# Altium schematic sheet 2-2  辅助电路.SchDoc("2-2  辅助电路")
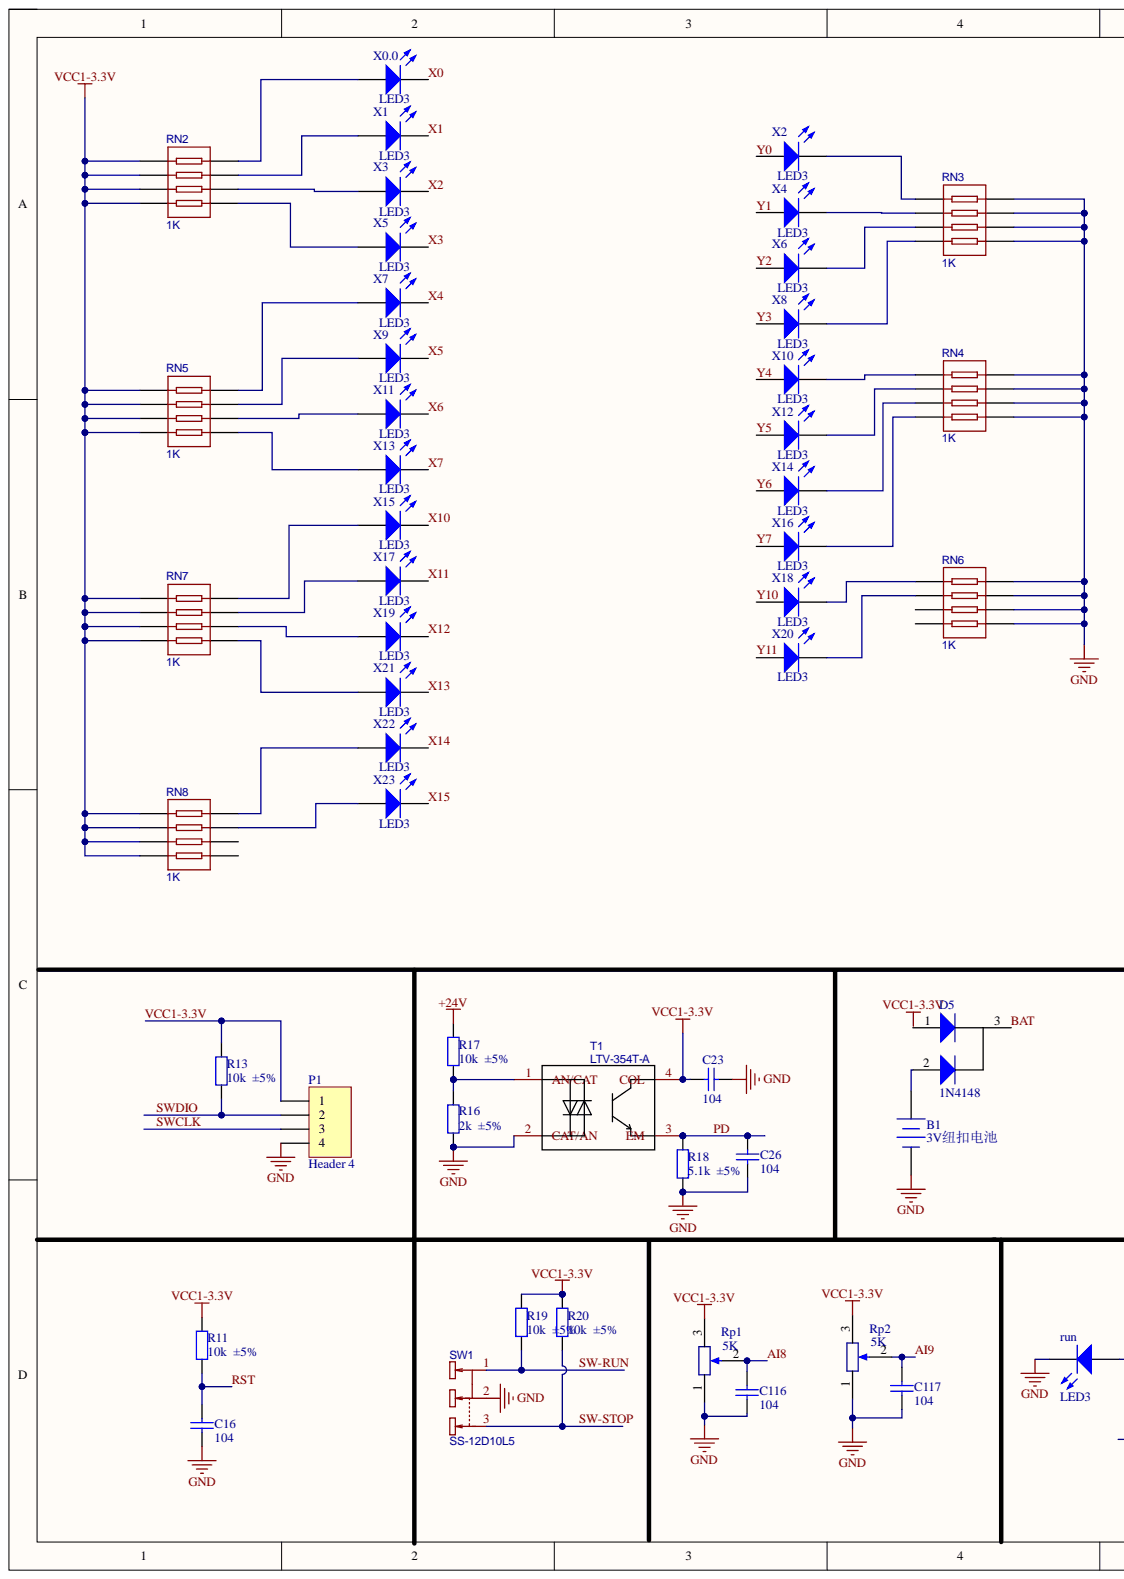
[diagram p0: 2-2  辅助电路.SchDoc, left]
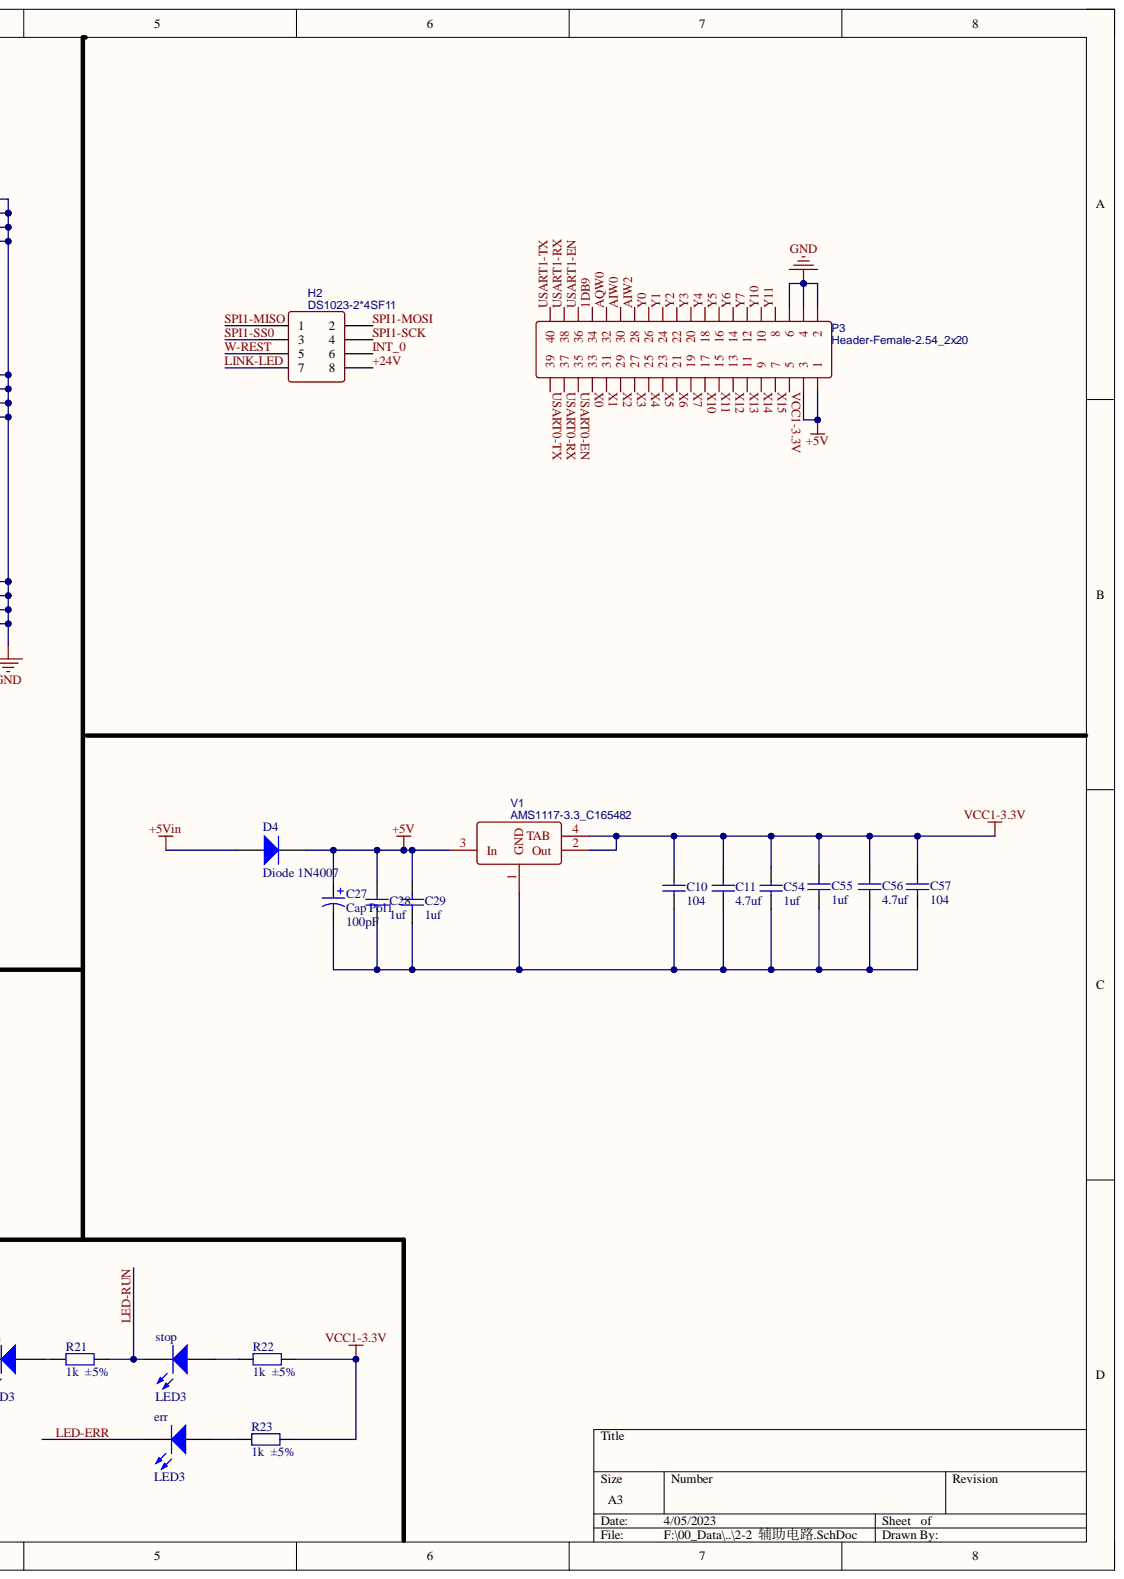
[diagram p1: 2-2  辅助电路.SchDoc, right]

## Parts
B1  3V纽扣电池  [Battery_3V固定底座]
C10  104  [1206_C]
C11  4.7uf  [1206_C]
C16  104  [0805_C]
C23  104  [0805_C]
C26  104  [0805_C]
C27  Cap Pol1  value 100pF  [RB7.6-15]
C28  1uf  [1206_C]
C29  1uf  [1206_C]
C54  1uf  [1206_C]
C55  1uf  [1206_C]
C56  4.7uf  [1206_C]
C57  104  [1206_C]
C116  104  [0805_C]
C117  104  [0805_C]
D4  Diode 1N4007  [DO-41]
D5  1N4148  [SOT-23(SOT-23-3)]
err  LED3  [3.5X2.8X1.9]
H2  DS1023-2*4SF11  [HDR-TH_8P-P2.54-V-R2-C4-S2.54]
P1  Header 4  [HDR1X4]
P3  Header-Female-2.54_2x20  [HDR-TH_40P-P2.54-V-R2-C20-S2.54]
R11  10k  ±5%  [0603_R]
R13  10k  ±5%  [0603_R]
R16  2k  ±5%  [0603_R]
R17  10k  ±5%  [0603_R]
R18  5.1k  ±5%  [0603_R]
R19  10k  ±5%  [0603_R]
R20  10k  ±5%  [0603_R]
R21  1k  ±5%  [0603_R]
R22  1k  ±5%  [0603_R]
R23  1k  ±5%  [0603_R]
RN2  1K  [RES-ARRAY-SMD_0603-8P-L3.2-W1.6-BL]
RN3  1K  [RES-ARRAY-SMD_0603-8P-L3.2-W1.6-BL]
RN4  1K  [RES-ARRAY-SMD_0603-8P-L3.2-W1.6-BL]
RN5  1K  [RES-ARRAY-SMD_0603-8P-L3.2-W1.6-BL]
RN6  1K  [RES-ARRAY-SMD_0603-8P-L3.2-W1.6-BL]
RN7  1K  [RES-ARRAY-SMD_0603-8P-L3.2-W1.6-BL]
RN8  1K  [RES-ARRAY-SMD_0603-8P-L3.2-W1.6-BL]
Rp1  5K  [RP-3362]
Rp2  5K  [RP-3362]
run  LED3  [3.5X2.8X1.9]
stop  LED3  [3.5X2.8X1.9]
SW1  SS-12D10L5  [SW-TH_SS-12D10L5]
T1  LTV-354T-A  [SOP-4_L3.9-W4.4-P2.54-LS7.0-BR]
V1  AMS1117-3.3_C165482  [SOT-223_L6.5-W3.5-P2.30-LS7.0-BR]
X0.0  LED3  [3.5X2.8X1.9]
X1  LED3  [3.5X2.8X1.9]
X2  LED3  [3.5X2.8X1.9]
X3  LED3  [3.5X2.8X1.9]
X4  LED3  [3.5X2.8X1.9]
X5  LED3  [3.5X2.8X1.9]
X6  LED3  [3.5X2.8X1.9]
X7  LED3  [3.5X2.8X1.9]
X8  LED3  [3.5X2.8X1.9]
X9  LED3  [3.5X2.8X1.9]
X10  LED3  [3.5X2.8X1.9]
X11  LED3  [3.5X2.8X1.9]
X12  LED3  [3.5X2.8X1.9]
X13  LED3  [3.5X2.8X1.9]
X14  LED3  [3.5X2.8X1.9]
X15  LED3  [3.5X2.8X1.9]
X16  LED3  [3.5X2.8X1.9]
X17  LED3  [3.5X2.8X1.9]
X18  LED3  [3.5X2.8X1.9]
X19  LED3  [3.5X2.8X1.9]
X20  LED3  [3.5X2.8X1.9]
X21  LED3  [3.5X2.8X1.9]
X22  LED3  [3.5X2.8X1.9]
X23  LED3  [3.5X2.8X1.9]

## Nets
+5V: C27.1 C28.2 C29.2 D4.2 P3.1 P3.3 V1.3(In)
+5Vin: D4.1
GND: B1.2 C16.2 C23.2 C26.1 C116.1 C117.1 P1.4 P3.2 P3.4 P3.6 R16.1 R18.2 RN3.1 RN3.2 RN3.3 RN3.4 RN4.1 RN4.2 RN4.3 RN4.4 RN6.1 RN6.2 RN6.3 RN6.4 Rp1.1 Rp2.1 run.2 SW1.2 T1.2(CAT/AN)
1DB9: P3.34
+24V: H2.8 R17.1
AI8: C116.2 Rp1.2
AI9: C117.2 Rp2.2
AIW0: P3.30
AIW2: P3.28
AQW0: P3.32
BAT: D5.3
INT_0: H2.6
LED-ERR: err.2
LED-RUN: R21.2 stop.2
LINK-LED: H2.7
PD: C26.2 R18.1 T1.3(EM)
RST: C16.1 R11.1
SPI1-MISO: H2.1
SPI1-MOSI: H2.2
SPI1-SCK: H2.4
SPI1-SS0: H2.3
SW-RUN: R19.2 SW1.1
SW-STOP: R20.2 SW1.3
SWCLK: P1.3
SWDIO: P1.2 R13.1
USART0-EN: P3.35
USART0-RX: P3.37
USART0-TX: P3.39
USART1-EN: P3.36
USART1-RX: P3.38
USART1-TX: P3.40
VCC1-3.3V: C10.2 C11.2 C23.1 C54.2 C55.2 C56.2 C57.2 P1.1 P3.5 R11.2 R13.2 R19.1 R20.1 R22.1 R23.1 RN2.5 RN2.6 RN2.7 RN2.8 RN5.5 RN5.6 RN5.7 RN5.8 RN7.5 RN7.6 RN7.7 RN7.8 RN8.5 RN8.6 RN8.7 RN8.8 Rp1.3 Rp2.3 T1.4(COL) V1.2(Out) V1.4(TAB)
W-REST: H2.5
X0: P3.33 X0.0.2
X1: P3.31 X1.2
X2: P3.29 X3.2
X3: P3.27 X5.2
X4: P3.25 X7.2
X5: P3.23 X9.2
X6: P3.21 X11.2
X7: P3.19 X13.2
X10: P3.17 X15.2
X11: P3.15 X17.2
X12: P3.13 X19.2
X13: P3.11 X21.2
X14: P3.9 X22.2
X15: P3.7 X23.2
Y0: P3.26 X2.1
Y1: P3.24 X4.1
Y2: P3.22 X6.1
Y3: P3.20 X8.1
Y4: P3.18 X10.1
Y5: P3.16 X12.1
Y6: P3.14 X14.1
Y7: P3.12 X16.1
Y10: P3.10 X18.1
Y11: P3.8 X20.1
NetB1_1: B1.1 D5.2
NetC10_1: C10.1 C11.1 C27.2 C28.1 C29.1 C54.1 C55.1 C56.1 C57.1 V1.1(GND)
Neterr_1: err.1 R23.2
NetR16_2: R16.2 R17.2 T1.1(AN/CAT)
NetR21_1: R21.1 run.1
NetR22_2: R22.2 stop.1
NetRN2_1: RN2.1 X5.1
NetRN2_2: RN2.2 X3.1
NetRN2_3: RN2.3 X1.1
NetRN2_4: RN2.4 X0.0.1
NetRN3_5: RN3.5 X2.2
NetRN3_6: RN3.6 X4.2
NetRN3_7: RN3.7 X6.2
NetRN3_8: RN3.8 X8.2
NetRN4_5: RN4.5 X10.2
NetRN4_6: RN4.6 X12.2
NetRN4_7: RN4.7 X14.2
NetRN4_8: RN4.8 X16.2
NetRN5_1: RN5.1 X13.1
NetRN5_2: RN5.2 X11.1
NetRN5_3: RN5.3 X9.1
NetRN5_4: RN5.4 X7.1
NetRN6_5: RN6.5 X18.2
NetRN6_6: RN6.6 X20.2
NetRN7_1: RN7.1 X21.1
NetRN7_2: RN7.2 X19.1
NetRN7_3: RN7.3 X17.1
NetRN7_4: RN7.4 X15.1
NetRN8_3: RN8.3 X23.1
NetRN8_4: RN8.4 X22.1

Not connected: D5 1; RN6 7 8; RN8 1 2

## Library data of the parts
B1 = Battery_3V: Multicell Battery; Component Kind: Standard; HelpURL: https://item.taobao.com/item.htm?spm=a230r.1.14.68.53d71be0dp6FL3&id=538548243439&ns=1&abbucket=20#detail; Library Name: 02-Miscellaneous_Devices_ZSF_Library.IntLib
C10 C11 C28 C29 C54-C57 = Cap_1206: 1206贴片电容; Component Kind: Standard; Library Name: 02-Miscellaneous_Devices_ZSF_Library.IntLib; Package: 0805; 常见贴片电容尺寸图: https://wenku.baidu.com/view/21d5206100f69e3143323968011ca300a6c3f6b2.html
  C10 C57: Value: 104
  C11 C56: Value: 4.7uf
  C28 C29 C54 C55: Value: 1uf
C16 C23 C26 C116 C117 = Cap_0805: 0805贴片电容; Component Kind: Standard; Library Name: 02-Miscellaneous_Devices_ZSF_Library.IntLib; Package: 0805; Value: 104; 常见贴片电容尺寸图: https://wenku.baidu.com/view/21d5206100f69e3143323968011ca300a6c3f6b2.html
C27 = Cap Pol1: Polarized Capacitor (Radial); Component Kind: Standard; LatestRevisionDate: 17-Jul-2002; LatestRevisionNote: Re-released for DXP Platform.; Library Name: Miscellaneous Devices.IntLib; PackageDescription: Polarized Capacitor (Radial); 2 Leads; PackageReference: RB7.6-15; Published: 8-Jun-2000; Signal Integrity: Cap Pol; Simulation: CAP; Publisher: Altium Limited
D4 = Diode 1N4007: 1 Amp General Purpose Rectifier; Code_JEDEC: DO-204-AL; Component Kind: Standard; ComponentLink1Description: Manufacturer Link; ComponentLink1URL: http://www.fairchildsemi.com/; ComponentLink2Description: Datasheet; ComponentLink2URL: http://www.fairchildsemi.com/ds/1N%2F1N4001.pdf; DatasheetVersion: 1998; LatestRevisionDate: 17-Jul-2002; LatestRevisionNote: Re-released for DXP Platform.; Library Name: Miscellaneous Devices.IntLib; PackageDescription: Diode, Axial; Body 5.2 x 2.7 mm (LxDia. max), Lead Dia. 0.86 mm (max); PackageReference: DO-41; PackageVersion: Aug-1999; Published: 8-Jun-2000; Signal Integrity: 1N4007; Simulation: 1N4007; Publisher: Altium Limited
D5 = BAV70 A4 SOT-23: 开关二极管; Component Kind: Standard; ComponentLink1Description: 供应商链接; ComponentLink1URL: http://www.szlcsc.com/product/details_70091.html; Library Name: 02-Miscellaneous_Devices_ZSF_Library.IntLib; Package: SOT-23; Supplier: LC; SuppliersPartNumber: C68978
err run stop X0.0 X1-X23 = LED3: Typical BLUE SiC LED; Component Kind: Standard; DatasheetVersion: 16-Apr-2002; LatestRevisionDate: 17-Dec-2003; LatestRevisionNote: PCB Footprint replaced.; Library Name: Miscellaneous Devices.IntLib; PackageDescription: SM LED; 2 C-Bend Leads; Body 3.5 x 2.8 x 1.9 mm, inc leads (LxWxH typ); PackageReference: 3.5X2.8X1.9; PackageVersion: 16-Apr-2002; Published: 8-Jun-2000; Signal Integrity: LED; Simulation: LED3; Publisher: Altium Limited
H2 = DS1023-2*4SF11: BOM_Manufacturer: CONNFLY; Contributor: LCSC; BOM_JLCPCB Part Class: Extended Part; BOM_Manufacturer Part: DS1023-2*4SF11; BOM_Supplier: LCSC; BOM_Supplier Part: C92271; Component Kind: Standard; spicePre: H; spiceSymbolName: DS1023-2*4SF11
P1 = Header 4: Header, 4-Pin; Component Kind: Standard; LatestRevisionDate: 17-Jul-2002; LatestRevisionNote: Re-released for DXP Platform.; Library Name: Miscellaneous Connectors.IntLib; Signal Integrity: Connector; Publisher: Altium Limited
P3 = Header-Female-2.54_2x20: BOM_Manufacturer: BOOMELE; BOM_Manufacturer Part: 2.54mm 2*20PFemale header; BOM_JLCPCB Part Class: Extended Part; BOM_Supplier: LCSC; BOM_Supplier Part: C50982; Component Kind: Standard; spicePre: P; spiceSymbolName: Header-Female-2.54_2x20
R11 R13 R16-R23 = Res_0603: 贴片电阻; Component Kind: Standard; ComponentLink1Description: 供应商链接; ComponentLink1URL: http://www.szlcsc.com/product/details_21903.html; Library Name: 02-Miscellaneous_Devices_ZSF_Library.IntLib; Package: 0603; Supplier: LC; SuppliersPartNumber: C21189
RN2-RN8 = 4.7K: BOM_Manufacturer: Ever Ohms Tech; BOM_Manufacturer Part: CRA034RF4K7P05; Contributor: LCSC; nameAlias: Resistance (Ohms); BOM_JLCPCB Part Class: Extended Part; BOM_Supplier: LCSC; BOM_Supplier Part: C149871; Component Kind: Standard; spicePre: R; spiceSymbolName: CRA034RF4K7P05
Rp1 Rp2 = RP_3362: 3296电位器; Component Kind: Standard; Library Name: 02-Miscellaneous_Devices_ZSF_Library.IntLib; 购买链接: https://detail.tmall.com/item.htm?spm=a230r.1.14.6.6b126f99GzSWkb&id=551070304702&cm_id=140105335569ed55e27b&abbucket=13
SW1 = SS-12D10L5: BOM_Manufacturer: XKB Enterprise; Contributor: LCSC; BOM_JLCPCB Part Class: Extended Part; BOM_Manufacturer Part: SS-12D10L5; BOM_Supplier: LCSC; BOM_Supplier Part: C319012; Component Kind: Standard; JLCPCB Part Class: Extended Part; spicePre: S; spiceSymbolName: SS-12D10L5
T1 = LTV-354T-A: BOM_Manufacturer: LITEON; BOM_JLCPCB Part Class: Extended Part; BOM_Manufacturer Part: LTV-354T-A; BOM_Supplier: LCSC; BOM_Supplier Part: C10802; Component Kind: Standard; JLCPCB Part Class: Extended Part; spicePre: U; spiceSymbolName: LTV-354T-A
V1 = AMS1117-3.3_C165482: BOM_Manufacturer: SK; Contributor: LCSC; BOM_JLCPCB Part Class: Extended Part; BOM_Manufacturer Part: AMS1117-3.3; BOM_Supplier: LCSC; BOM_Supplier Part: C165482; Component Kind: Standard; spicePre: U; spiceSymbolName: AMS1117-3.3_C165482
